# Altium schematic sheet Sch-QWA17_USB Hub.SchDoc("Sch-QWA17_USB Hub")
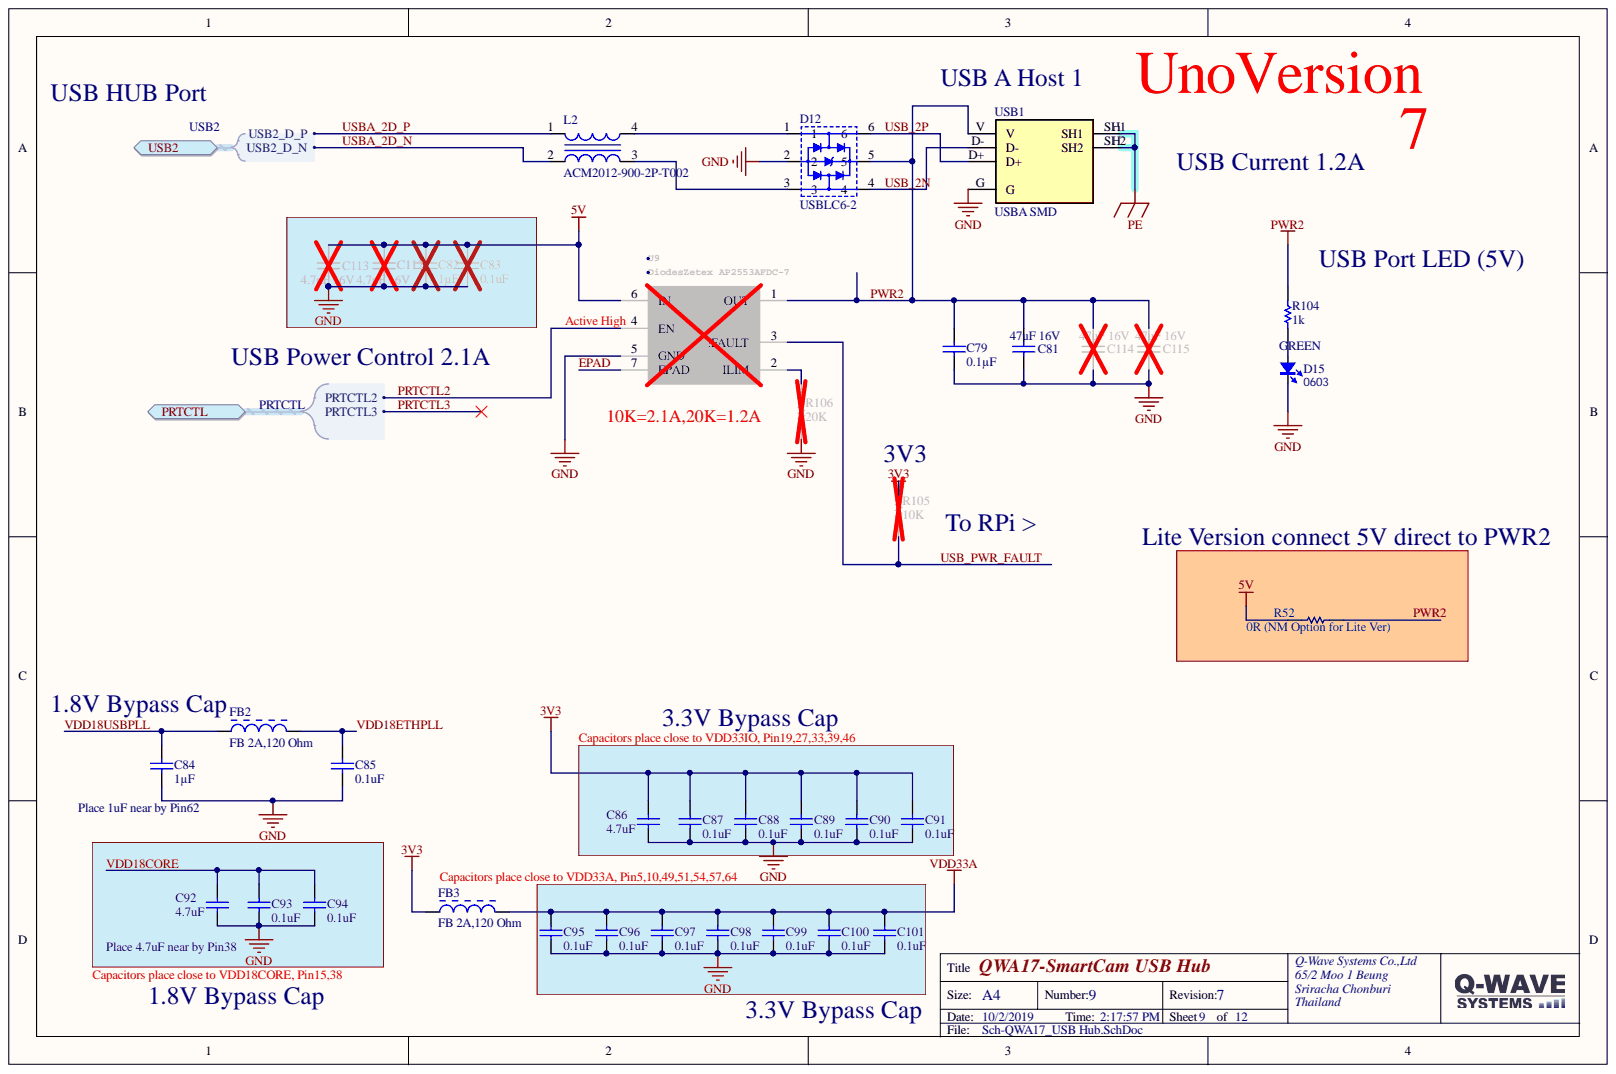
[diagram p0: Sch-QWA17_USB Hub.SchDoc]

## Parts
C79  0.1µF  [CAP_0603]
C81  47uF 16V  value 47uF  [1210C-GENERIC]
C82  1µF  [CAP_0603]
C83  0.1uF  [CAP_0603]
C84  1µF  [CAP_0603]
C85  0.1uF  [CAP_0603]
C86  4.7uF  [6-0805_N]
C87  0.1uF  [CAP_0603]
C88  0.1uF  [CAP_0603]
C89  0.1uF  [CAP_0603]
C90  0.1uF  [CAP_0603]
C91  0.1uF  [CAP_0603]
C92  4.7uF  [6-0805_N]
C93  0.1uF  [CAP_0603]
C94  0.1uF  [CAP_0603]
C95  0.1uF  [CAP_0603]
C96  0.1uF  [CAP_0603]
C97  0.1uF  [CAP_0603]
C98  0.1uF  [CAP_0603]
C99  0.1uF  [CAP_0603]
C100  0.1uF  [CAP_0603]
C101  0.1uF  [CAP_0603]
C112  4.7uF 16V  [CAP_0805]
C113  4.7uF 16V  [CAP_0805]
C114  47uF 16V  value 47uF  [1210C-GENERIC]
C115  47uF 16V  value 47uF  [1210C-GENERIC]
D12  USBLC6-2  [SOT-666]
D15  GREEN  Type: 0603  [LED_0603_GREEN]
FB2  FB 2A,120 Ohm  [FU 0805]
FB3  FB 2A,120 Ohm  [FU 0805]
L2  ACM2012-900-2P-T002  [ACM2012]
R52  0R (NM Option for Lite Ver)  [RES_0603]
R104  1k  [RES_0603]
R105  10K  [RES_0603]
R106  20K  [RES_0603]
U9  DiodesZetex AP2553AFDC-7  [SON65P201X201X63-7N]
USB1  USBA SMD  [USB-A/S_B]

## Ports
PRTCTL, PRTCTL.PRTCTL2, PRTCTL.PRTCTL3, USB2, USB2.USB2_D_N, USB2.USB2_D_P

## Nets
3V3: C86.2 C87.2 C88.2 C89.2 C90.2 C91.2 FB3.1 R105.2
5V: C82.2 C83.2 C112.1 C113.1 R52.1 U9.6(IN)
GND: C79.1 C81.1 C82.1 C83.1 C84.1 C85.1 C86.1 C87.1 C88.1 C89.1 C90.1 C91.1 C92.1 C93.1 C94.1 C95.1 C96.1 C97.1 C98.1 C99.1 C100.1 C101.1 C112.2 C113.2 C114.1 C115.1 D12.2 D15.2 R106.1 U9.5(GND) USB1.G
PE: USB1.SH1 USB1.SH2
VDD33A: C95.2 C96.2 C97.2 C98.2 C99.2 C100.2 C101.2 FB3.2
EPAD: U9.7(EPAD)
PRTCTL2: U9.4(EN) ; port PRTCTL
PWR2: C79.2 C81.2 C114.2 C115.2 D12.5 R52.2 R104.2 U9.1(OUT) USB1.V
USB_2N: D12.4 USB1.D-
USB_2P: D12.6 USB1.D+
USB_PWR_FAULT: R105.1 U9.3(!FAULT)
USBA_2D_N: L2.2 ; port USB2
USBA_2D_P: L2.1 ; port USB2
VDD18CORE: C92.2 C93.2 C94.2
VDD18ETHPLL: C85.2 FB2.2
VDD18USBPLL: C84.2 FB2.1
NetD12_1: D12.1 L2.4
NetD12_3: D12.3 L2.3
NetD15_1: D15.1 R104.1
NetR106_2: R106.2 U9.2(ILIM)
PRTCTL3: ; port PRTCTL

## Library data of the parts
C79 = Cap Semi: 0.11µF ±5% 50V Ceramic Capacitor X7R 0603; MFG: KEMET; MFG P/N: C0603C104J5RACTU; Component Kind: Standard; Library Name: Miscellaneous Devices.IntLib; Simulation: CAP
C81 C114 C115 = Cap: Capacitor 1210; Part Number: 0805F476M100NT; Component Kind: Standard; LatestRevisionDate: 17-Jul-2002; LatestRevisionNote: Re-released for DXP Platform.; Library Name: Miscellaneous Devices.IntLib; PackageDescription: Radial Cap, Thru-Hole; 2 Leads; 0.3 in Pin Spacing; PackageReference: RAD-0.3; Published: 8-Jun-2000; Simulation: CAP; Supplier: LCSC; Publisher: Altium Limited
C82 C84 = Cap Semi: 1µF ±5% 50V Ceramic Capacitor X7R 0603; MFG: KEMET; MFG P/N: C0603C104J5RACTU; Component Kind: Standard; Library Name: Miscellaneous Devices.IntLib; Simulation: CAP
C83 C85 C87-C91 C93-C101 = Cap Semi: 0.1µF ±5% 50V Ceramic Capacitor X7R 0603; MFG: KEMET; MFG P/N: C0603C104J5RACTU; Component Kind: Standard; Library Name: Miscellaneous Devices.IntLib; Simulation: CAP
C86 C92 = Cap: Capacitor; Part Number: GRM188R61A475KE15D; ClassName: uSD_slot; Component Kind: Standard; LatestRevisionDate: 17-Jul-2002; LatestRevisionNote: Re-released for DXP Platform.; Library Name: Miscellaneous Devices.IntLib; PackageDescription: Radial Cap, Thru-Hole; 2 Leads; 0.3 in Pin Spacing; PackageReference: RAD-0.3; Published: 8-Jun-2000; Simulation: CAP; Supplier: LCSC; Value: 4.7uF; Publisher: Altium Limited
C112 C113 = Cap: 4.7µF ±10% 16V Ceramic Capacitor X5R 0805; MFG: KEMET; MFG P/N: C0603C475K8PACTU; Component Kind: Standard; Library Name: Miscellaneous Devices.IntLib; Simulation: CAP
D12 = USBLC6-2: TVS DIODE 5.25V 17V SOT23-6; : USBLC6-2SC6; Component Kind: Standard; Library Name: x-io.SchLib
D15 = LED_0603: LED; Value: color?; Component Kind: Standard; Library Name: SCH_Active.SchLib
FB2 FB3 = CMP-0686-00557-6: BLM18PG121SN1D Chip Ferrite Bead for Power Lines, 120 Ohm, 2000 mA, -55 to 125 degC, 1.6 x 0.8 x 0.95 mm SMD, Tape and Reel; Manufacturer: Murata; DC Resistance: 0.05 Ohm Max.; Impedance (at 100 MHz): 120 Ohm +/- 25%; Rated Current: 2000 mA; Component Kind: Standard; ComponentLink1Description: Manufacturer URL; ComponentLink1URL: http://www.murata.com/; ComponentLink2Description: Datasheet; ComponentLink2URL: http://www.murata.com/products/catalog/pdf/c31e.pdf; ComponentLink3Description: Package Specification; ComponentLink3URL: http://www.murata.com/products/catalog/pdf/c31e.pdf; DatasheetVersion: 08/2013; Library Name: Altium Content Vault; Mounting Technology: Surface Mount; Operating Temperature Range: -55 to 125 degC; PackageDescription: 2-Pin Chip, Body 1.6 x 0.8 mm; PackageReference: BLM18_H950-2; Packing: Tape and Reel; PartNumber: BLM18PG121SN1D
L2 = ACM2012-900-2P-T002: CHOKE COMM MODE 90 OHM .4A SMD; Manufacturer: TDK Corporation; .Checked PCB: RF; Current: 400mA; DC Resistance (DCR): 190 mOhm Max; Filter Type: Data, Signal Line; Impedance: 90 Ohm; Number of Lines: 2; Power (Watts): 0.063W, 1/16W; Resistance (Ohms): 8.2K; Temperature Coefficient: ฑ100ppm/ฐC; Tolerance: ฑ1%; Category: Filters; Component Kind: Standard; ComponentLink1Description: http://www.tdk.co.jp/tefe02/e9719_acm2012.pdf; ComponentLink1URL: http://www.tdk.co.jp/tefe02/e9719_acm2012.pdf; ComponentLink2Description: http://www.yageo.com/documents/recent/PYu-RC0402_51_RoHS_L_6.pdf; ComponentLink2URL: http://www.yageo.com/documents/recent/PYu-RC0402_51_RoHS_L_6.pdf; Composition: Thick Film; Features: -; Height: 0.016" (0.40mm); Height (Max): 0.051" (1.30mm); Inductance: -; Manufacturer Part Number: ACM2012-900-2P-T002; Mounting Type: Surface Mount; Number of Terminations: 2; Operating Temperature: -25ฐC ~ 85ฐC; Package / Case: 0805 (2012 Metric), 4 Lead; Packaging: Cut Tape (CT); Pricing 1: 1=0.48, 10=0.448, 50=0.42, 100=0.364, 500=0.308, 1000=0.252 (USD); RoHS: RoHS Compliant; Size / Dimension: 0.079" L x 0.047" W (2.00mm x 1.20mm); Stock 1: 175768; Supplier 1: Digi-Key; Supplier Part Number 1: 445-2207-1-ND; .Checked SCH: RF; Library Name: iMX6 Rex Development Baseboard V1I1.IntLib
R52 R105 R106 = Res Semi: Resistor 0603; Component Kind: Standard; Library Name: Miscellaneous Devices.IntLib; Simulation: RES
R104 = Res Semi: 1k Resistor 0603; Component Kind: Standard; Library Name: SCH_Passive.SchLib; Simulation: RES
U9 = AP2553AFDC-7: Power Distribution 2.1A Precision ADJ 5.5V 2.1A; Manufacturer_Name: Diodes Inc.; GateName_1: G$1; Component Kind: Standard; DeviceSetName: AP2553AFDC-7; Height: 0.63mm; Library Name: AP2553AFDC-7.IntLib; LibraryName: AP2553AFDC-7; Manufacturer_Part_Number: AP2553AFDC-7; Mouser_Part_Number: 621-AP2553AFDC-7; Mouser_Price-Stock: https://www.mouser.com/Search/Refine.aspx?Keyword=621-AP2553AFDC-7; SymbolName_1: AP2553AFDC-7
USB1 = USBA SMD: UMRA04FTWNY-03; ES P/N: 0007-1463-7; Component Kind: Standard; ES Link: https://www.es.co.th/detail.asp?Prod=000714637; P/N: UMRA04FTWNY-03; Library Name: QwaveLib.SchLib
